# Altium schematic sheet Controller_Board.SchDoc(Controller_Board)
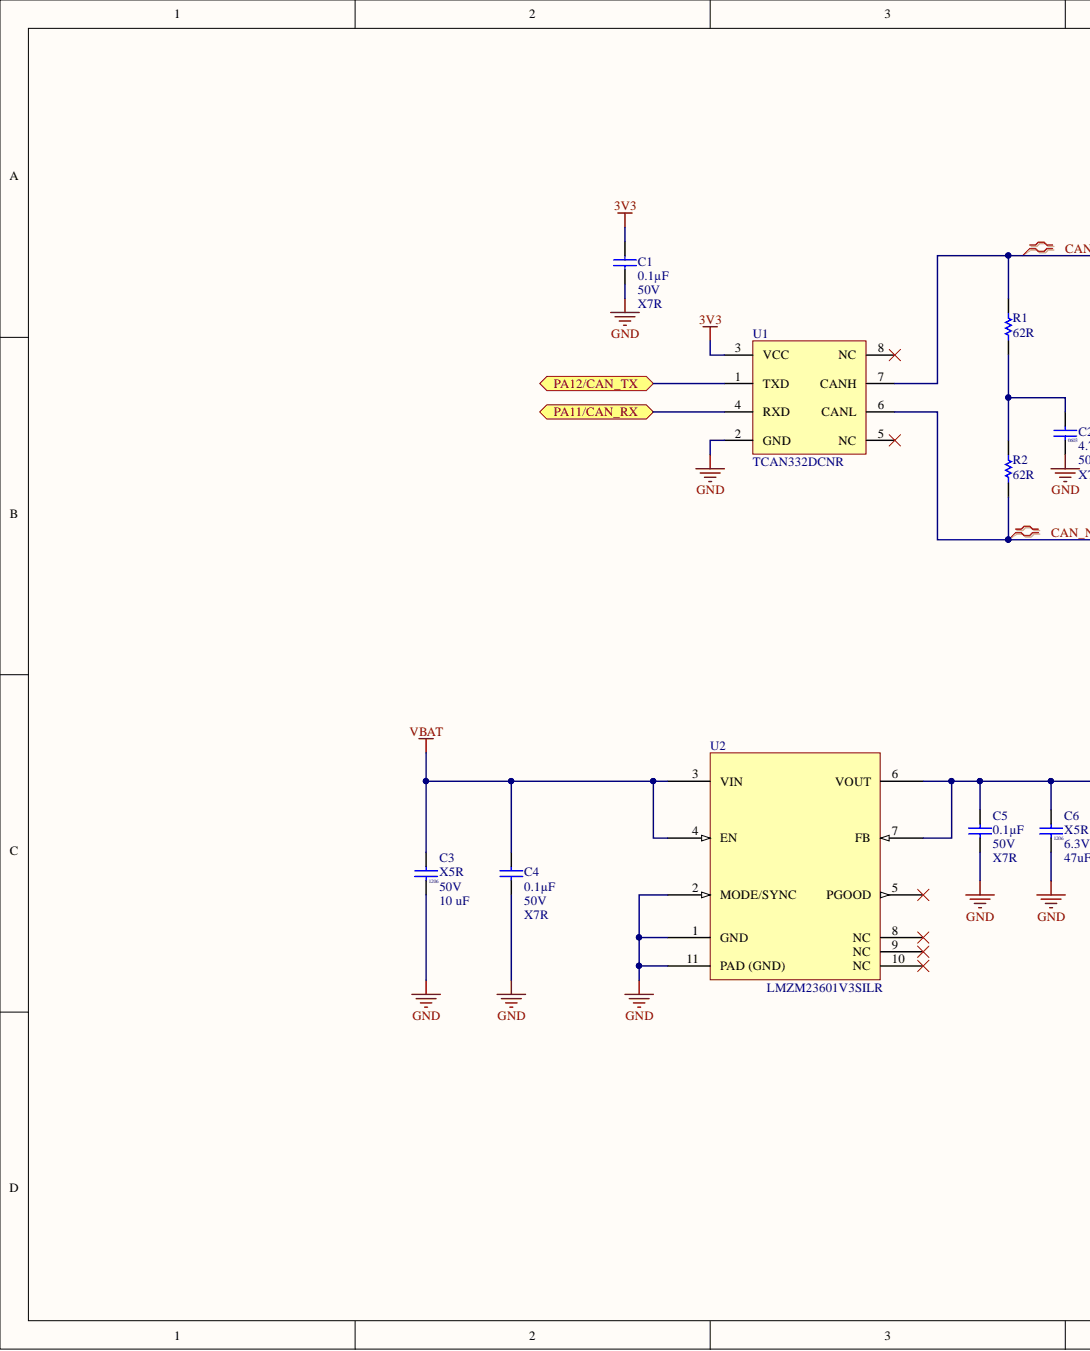
[diagram p0: Controller_Board.SchDoc, left]
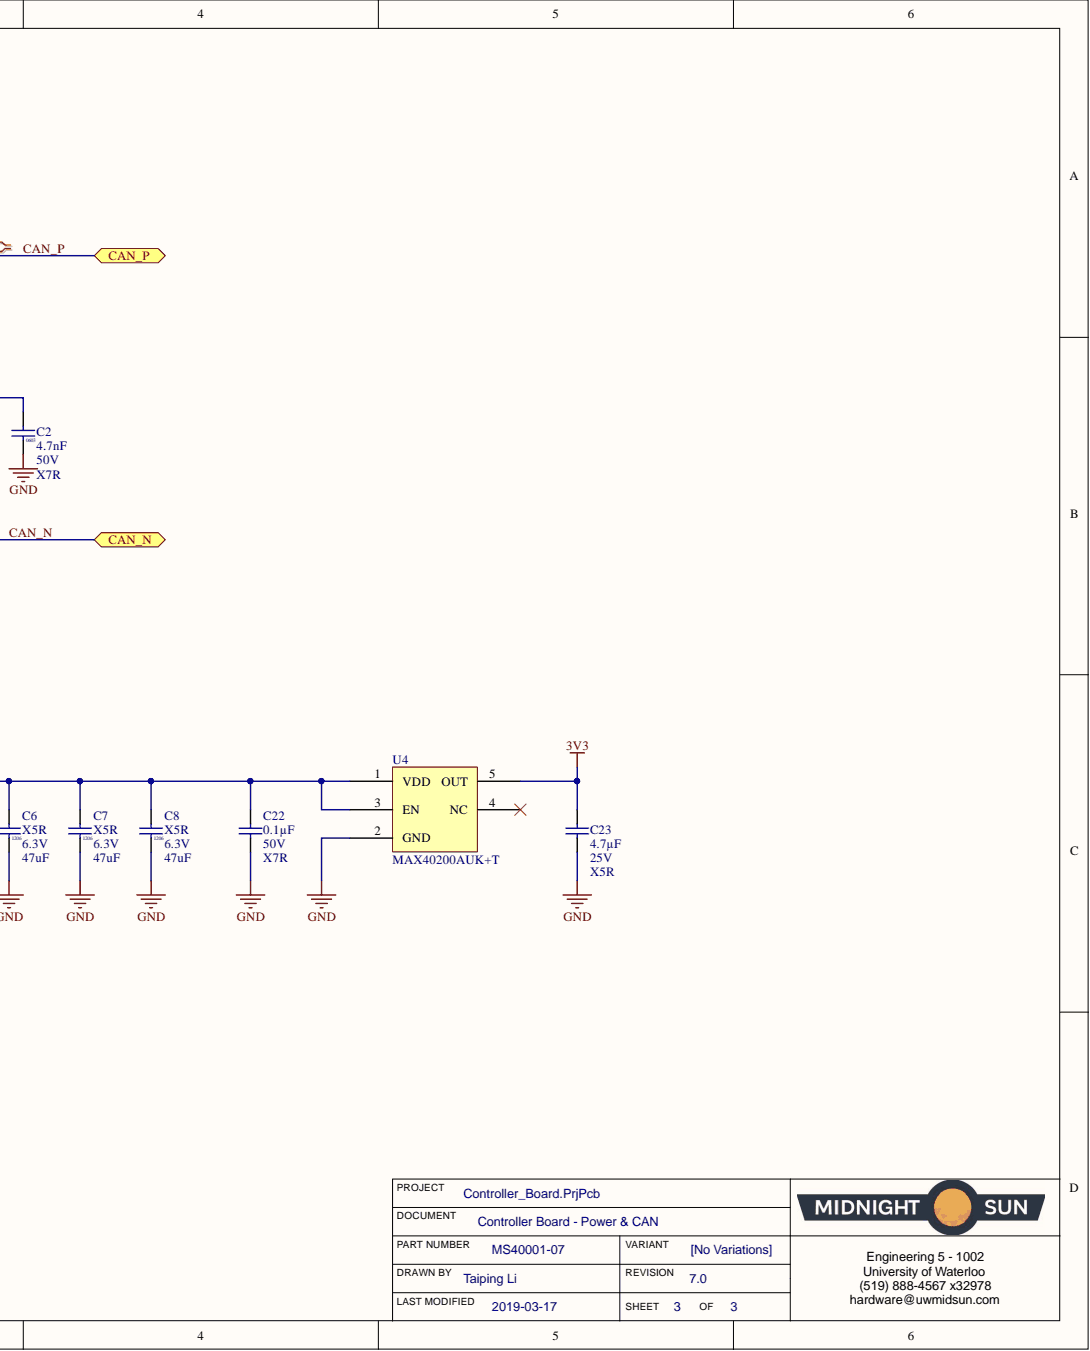
[diagram p1: Controller_Board.SchDoc, right]

## Parts
C1  Voltage: 50V  Temperature Coefficient: X7R  Capacitance: 0.1µF  [CAP, 0603]  (hidden comment: CAP CER 0.1UF 50V 10% X7R 0603)
C2  Voltage Rating (DC): 50V  Dielectric: X7R  Case Code (Imperial): 0603  Capacitance: 4.7nF  [CAP, 0603]  (hidden comment: CAP CER 4.7nF 50V 10% X7R 0603)
C3  Voltage Rating: 50V  Rating: X5R  Case Code (Imperial): 1206  Capacitance: 10 uF  [CAP, 1206]  (hidden comment: CAP CER 10uF 50V 20% X5R 1206)
C4  Voltage: 50V  Temperature Coefficient: X7R  Capacitance: 0.1µF  [CAP, 0603]  (hidden comment: CAP CER 0.1UF 50V 10% X7R 0603)
C5  Voltage: 50V  Temperature Coefficient: X7R  Capacitance: 0.1µF  [CAP, 0603]  (hidden comment: CAP CER 0.1UF 50V 10% X7R 0603)
C6  Voltage Rating: 6.3V  Dielectric: X5R  Case Code (Imperial): 1206  Capacitance: 47uF  [CAP, 1206]  (hidden comment: CAP CER 47uF 6.3V X5R 1206)
C7  Voltage Rating: 6.3V  Dielectric: X5R  Case Code (Imperial): 1206  Capacitance: 47uF  [CAP, 1206]  (hidden comment: CAP CER 47uF 6.3V X5R 1206)
C8  Voltage Rating: 6.3V  Dielectric: X5R  Case Code (Imperial): 1206  Capacitance: 47uF  [CAP, 1206]  (hidden comment: CAP CER 47uF 6.3V X5R 1206)
C22  Voltage: 50V  Temperature Coefficient: X7R  Capacitance: 0.1µF  [CAP, 0603]  (hidden comment: CAP CER 0.1UF 50V 10% X7R 0603)
C23  Voltage: 25V  Temperature Coefficient: X5R  Capacitance: 4.7µF  [CAP, 0603]  (hidden comment: CAP CER 4.7UF 25V 10% X5R 0603)
R1  62R  [RES, 0603]
R2  62R  [RES, 0603]
U1  Manufacturer Part Number: TCAN332DCNR  [TSOP65P280X145-8N]  (hidden comment: IC CAN Tranceiver TCAN332DR)
U2  Manufacturer Part Number: LMZM23601V3SILR  [IC DCDC 3.3V 1A 10-uSIP]  (hidden comment: IC DC DC 3.3V 1A 10-uSIP)
U4  Manufacturer Part Number: MAX40200AUK+T  [SOT95P280X145-5N]  (hidden comment: IC IDEAL DIODE CURRENT SWITCH SOT23-5)

## Ports
CAN_N, CAN_P, PA11/CAN_RX, PA12/CAN_TX

## Nets
3V3: C1.1 C23.1 U1.3(VCC) U4.5(OUT)
GND: C1.2 C2.2 C3.2 C4.2 C5.2 C6.2 C7.2 C8.2 C22.2 C23.2 U1.2(GND) U2.1(GND) U2.2(MODE/SYNC) U2.11(PAD_(GND)) U4.2(GND)
VBAT: C3.1 C4.1 U2.3(VIN) U2.4(EN)
CAN_N: R2.2 U1.6(CANL) ; port CAN_N
CAN_P: R1.1 U1.7(CANH) ; port CAN_P
PA11/CAN_RX: U1.4(RXD) ; port PA11/CAN_RX
PA12/CAN_TX: U1.1(TXD) ; port PA12/CAN_TX
NetC2_1: C2.1 R1.2 R2.1
NetC5_1: C5.1 C6.1 C7.1 C8.1 C22.1 U2.6(VOUT) U2.7(FB) U4.1(VDD) U4.3(EN)

Not connected: U1 5(NC) 8(NC); U2 5(PGOOD) 8(NC) 9(NC) 10(NC); U4 4(NC)

## Library data of the parts
C1 C4 C5 C22 = CAP CER 0.1UF 50V 10% X7R 0603: 0.10µF ±10% 50V Ceramic Capacitor X7R 0603 (1608 Metric); Manufacturer Part Number 1: 06035C104KAT2A; Manufacturer 1: AVX Corporation; Tolerance: ±10%; Thickness (Max): 0.035" (0.90mm); Supplier Part Number 1: 478-5052-1-ND; Supplier 1: Digi-Key; Size / Dimension: 0.063" L x 0.032" W (1.60mm x 0.81mm); Ratings: -; Part Status: Active; Packaging: Cut Tape (CT); Package / Case: 0603 (1608 Metric); Operating Temperature: -55°C ~ 125°C; Mounting Type: Surface Mount, MLCC; Lead Style: -; Lead Spacing: -; Height - Seated (Max): -; ComponentLink1URL: http://datasheets.avx.com/X7RDielectric.pdf; ComponentLink1Description: http://datasheets.avx.com/X7RDielectric.pdf; Component Kind: Standard; Library Name: Schematic Diagrams.SchLib
C2 = CAP CER 4.7nF 50V 10% X7R 0603: 4700pF ±10% 50V Ceramic Capacitor X7R 0603 (1608 Metric); Manufacturer Part Number: GCM188R71H472KA37D; Manufacturer: Murata; Width: 0.031inch; Weight: 7.1E-05oz; Voltage Rating: 50V; Voltage: 50V; Tolerance: 10%; Thickness: 0.035inch; Termination: SMD/SMT; Supplier Part Number 1: 490-4932-1-ND; Supplier 1: Digi-Key; Stock 1: 824762; RoHS: Yes; REACH SVHC: No SVHC; Ratings: AEC-Q200; Radiation Hardening: No; Pricing 1: 1 = 0.21000, 10 = 0.15100, 100 = 0.07080, 500 = 0.04964, 1000 = 0.04109 (USD); Packaging: Tape and Reel; Package Quantity: 1; Mount: Surface Mount; Min Operating Temperature: -55°C; Max Operating Temperature: 125°C; Length: 1.6mm; Lead Free: Lead Free; Height: 0.8mm; Depth: 0.8mm; ComponentLink4URL: http://psearch.murata.com/capacitor/product/GCM188R71H472KA37%23.pdf?src-supplier=Avnet; ComponentLink4Description: http://psearch.murata.com/capacitor/product/GCM188R71H472KA37%23.pdf?src-supplier=Avnet; ComponentLink3URL: https://www.verical.com/server-webapp/html/getDatasheet?partID=223879&src-supplier=Verical; ComponentLink3Description: https://www.verical.com/server-webapp/html/getDatasheet?partID=223879&src-supplier=Verical; ComponentLink2URL: http://uk.rs-online.com/webdocs/0ef9/0900766b80ef92d0.pdf?src-supplier=RSComponents; ComponentLink2Description: http://uk.rs-online.com/webdocs/0ef9/0900766b80ef92d0.pdf?src-supplier=RSComponents; ComponentLink1URL: http://search.murata.co.jp/Ceramy/image/img/A01X/partnumbering_e_02.pdf?src-supplier=Digi-Key; ComponentLink1Description: http://search.murata.co.jp/Ceramy/image/img/A01X/partnumbering_e_02.pdf?src-supplier=Digi-Key; Component Kind: Standard; Case Code (Metric): 1608; Case/Package: 0603; Library Name: Schematic Diagrams.SchLib
C3 = CAP CER 10uF 50V 20% X5R 1206: 10µF ±20% 50V Ceramic Capacitor X5R 1206 (3216 Metric); Manufacturer Part Number: GRT31CR61H106ME01L; Manufacturer: Murata; Width: 0.063inch; Tolerance: 20%; Thickness: 0.071inch; Supplier Part Number 1: 490-12457-1-ND; Supplier 1: Digi-Key; Stock 1: 449467; RoHS: Yes; Ratings: AEC-Q200; Pricing 1: 1 = 0.57000, 10 = 0.39800, 100 = 0.23910, 500 = 0.17930, 1000 = 0.15141 (USD); Packaging: Tape and Reel; Mount: Surface Mount; Min Operating Temperature: -55°C; Max Operating Temperature: 85°C; Length: 0.126inch; Component Kind: Standard; Case Code (Metric): 3216; Case/Package: 1206; Library Name: Schematic Diagrams.SchLib
C6-C8 = CAP CER 47uF 6.3V X5R 1206: 47µF ±20% 6.3V Ceramic Capacitor X5R 1206 (3216 Metric); Manufacturer Part Number: CL31A476MQHNNNE; Manufacturer: Samsung; Width: 0.063inch; Voltage: 6.3V; Tolerance: 20%; Thickness: 0.071inch; Supplier Part Number 1: 1276-1167-1-ND; Supplier 1: Digi-Key; Stock 1: 888566; RoHS: Yes; Radiation Hardening: No; Pricing 1: 1 = 0.44000, 10 = 0.30600, 100 = 0.18340, 500 = 0.13754, 1000 = 0.11614 (USD); Packaging: Tape and Reel; Package Quantity: 1; Mount: Surface Mount; Min Operating Temperature: -55°C; Max Operating Temperature: 85°C; Material: Ceramic; Length: 3.2mm; Height: 1.6mm; Depth: 1.6mm; Contact Plating: Tin; ComponentLink4URL: https://produktinfo.conrad.com/datenblaetter/450000-474999/452054-da-01-en-VS_KONDENSATOR_1206.pdf?src-supplier=Conrad; ComponentLink4Description: https://produktinfo.conrad.com/datenblaetter/450000-474999/452054-da-01-en-VS_KONDENSATOR_1206.pdf?src-supplier=Conrad; ComponentLink3URL: http://www1.futureelectronics.com/doc/SAMSUNG/CL31A476MQHNNNE.pdf?src-supplier=Future+Electronics; ComponentLink3Description: http://www1.futureelectronics.com/doc/SAMSUNG/CL31A476MQHNNNE.pdf?src-supplier=Future+Electronics; ComponentLink2URL: https://www.verical.com/server-webapp/html/getDatasheet?partID=358369&src-supplier=Verical; ComponentLink2Description: https://www.verical.com/server-webapp/html/getDatasheet?partID=358369&src-supplier=Verical; ComponentLink1URL: http://www.samsungsem.com/kr/support/product-search/mlcc/CL31A476MQHNNNE.jsp?src-supplier=Digi-Key; ComponentLink1Description: http://www.samsungsem.com/kr/support/product-search/mlcc/CL31A476MQHNNNE.jsp?src-supplier=Digi-Key; Component Kind: Standard; Case Code (Metric): 3216; Case/Package: 1206; Library Name: Schematic Diagrams.SchLib
C23 = CAP CER 4.7UF 25V 10% X5R 0603: 4.7µF ±10% 25V Ceramic Capacitor X5R 0603 (1608 Metric); Manufacturer Part Number 1: GRM188R61E475KE11D; Manufacturer 1: Murata Electronics North America; Tolerance: ±10%; Thickness (Max): 0.031" (0.80mm); Supplier Part Number 1: 490-7203-1-ND; Supplier 1: Digi-Key; Size / Dimension: 0.063" L x 0.031" W (1.60mm x 0.80mm); Ratings: -; Part Status: Active; Packaging: Cut Tape (CT); Package / Case: 0603 (1608 Metric); Operating Temperature: -55°C ~ 85°C; Mounting Type: Surface Mount, MLCC; Lead Style: -; Lead Spacing: -; Height - Seated (Max): -; ComponentLink1URL: http://www.murata.com/~/media/webrenewal/support/library/catalog/products/capacitor/mlcc/c02e.ashx; ComponentLink1Description: http://www.murata.com/~/media/webrenewal/support/library/catalog/products/capacitor/mlcc/c02e.ashx; Component Kind: Standard; Library Name: Schematic Diagrams.SchLib
R1 R2 = RES 62 OHM 0.1% 1/10W 0603: 62 Ohms ±0.1% 0.1W, 1/10W Chip Resistor 0603 (1608 Metric) Automotive AEC-Q200 Thin Film; Manufacturer Part Number: ERA-3AEB620V; Manufacturer: Panasonic Electronic Components; Value: 62; Temperature Coefficient: ±25ppm/°C; Tolerance: ±0.1%; Supplier Part Number 1: P62DBCT-ND; Supplier Device Package: 0603; Supplier 1: Digi-Key; Stock 1: 10320; Size / Dimension: 0.063" L x 0.032" W (1.60mm x 0.80mm); RoHS: RoHS Compliant; Pricing 1: 1=0.52, 10=0.447, 100=0.1748, 1000=0.07317, 2500=0.06708 (CAD); Power (Watts): 0.1W, 1/10W; Part Status: Active; Packaging: Cut Tape (CT); Package / Case: 0603 (1608 Metric); Operating Temperature: -55°C ~ 155°C; Height - Seated (Max): 0.022" (0.55mm); Features: Automotive AEC-Q200; Failure Rate: -; Composition: Thin Film; ComponentLink1URL: https://industrial.panasonic.com/cdbs/www-data/pdf/RDM0000/AOA0000C307.pdf; ComponentLink1Description: https://industrial.panasonic.com/cdbs/www-data/pdf/RDM0000/AOA0000C307.pdf; Component Kind: Standard; Category: Resistors; Library Name: Schematic Diagrams.SchLib
U1 = IC CAN Tranceiver TCAN332DR: 1/1 Transceiver CANbus SOT-23-8; Manufacturer: Texas Instruments; Supplier Part Number 1: 296-47355-1-ND; Supplier 1: Digi-Key; Stock 1: 2387; Pricing 1: 1 = 2.27000, 10 = 2.04200, 100 = 1.64100, 500 = 1.34828, 1000 = 1.11714 (USD); Min Operating Temperature: -40°C; Max Operating Temperature: 125°C; Lead Free: Lead Free; Component Kind: Standard; Library Name: Schematic Diagrams.SchLib
U2 = IC DC DC 3.3V 1A 10-uSIP: Non-Isolated PoL Module DC DC Converter 1 Output 3.3V 1A 4V - 36V Input; Manufacturer: Texas Instruments; Supplier Part Number 1: 296-51381-1-ND; Supplier 1: Digi-Key; Stock 1: 1291; Pricing 1: 1 = 5.76000, 10 = 5.17700, 100 = 4.24200, 500 = 3.61110, 1000 = 3.04550 (USD); Min Operating Temperature: -40°C; Max Operating Temperature: 125°C; ComponentLink1URL: http://www.ti.com/lit/ds/symlink/lmzm23601.pdf; ComponentLink1Description: Datasheet; Component Kind: Standard; Library Name: Schematic Diagrams.SchLib
U4 = IC IDEAL DIODE CURRENT SWITCH SOT23-5: OR Controller Source Selector Switch P-Channel 1:1 SOT-23-5; Manufacturer: Maxim; Supplier Part Number 1: MAX40200AUK+TCT-ND; Supplier 1: Digi-Key; Stock 1: 7780; Pricing 1: 1 = 0.93000, 10 = 0.82100, 100 = 0.62930, 500 = 0.49750, 1000 = 0.39799 (USD); ComponentLink1URL: https://datasheets.maximintegrated.com/en/ds/MAX40200.pdf; ComponentLink1Description: Datasheet; Component Kind: Standard
